# Altium schematic sheet Current_Measurement_AB.SchDoc(Current_Measurement_AB)
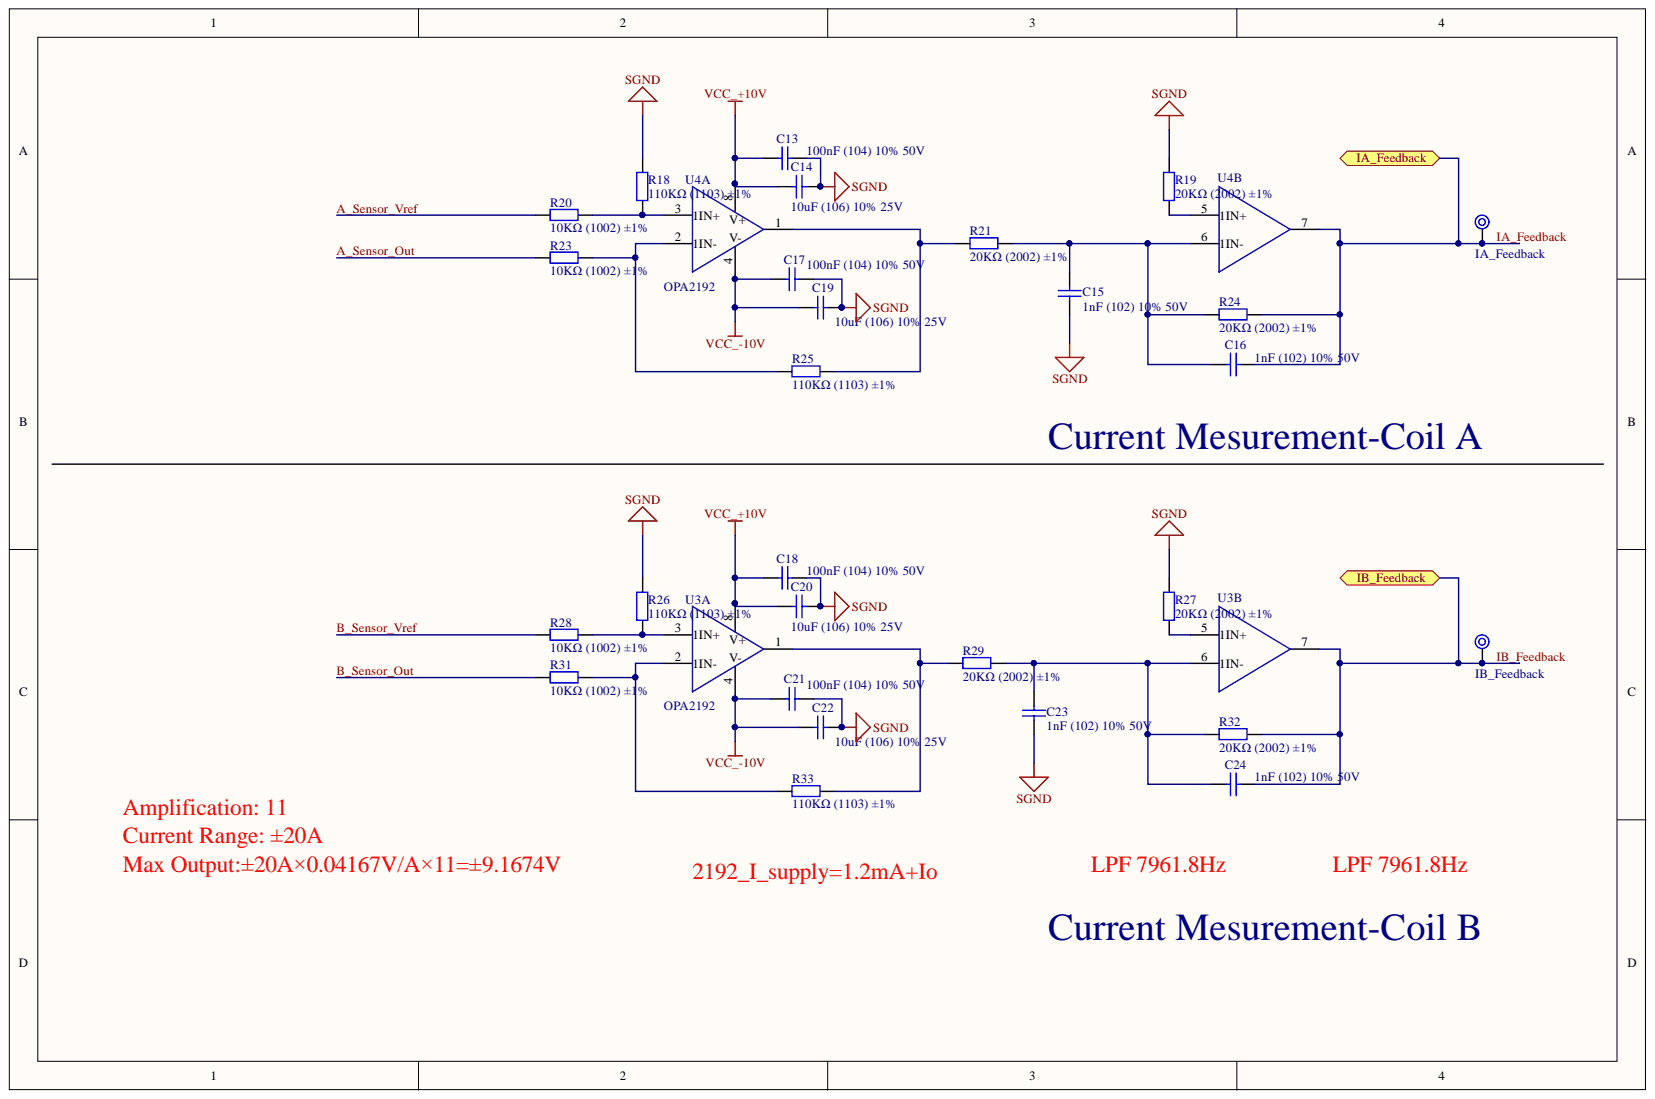
[diagram p0: Current_Measurement_AB.SchDoc]

## Parts
C13  100nF (104) 10% 50V  [0603_C]
C14  10uF (106) 10% 25V  [0805_C]
C15  1nF (102) 10% 50V  [0603_C]
C16  1nF (102) 10% 50V  [0603_C]
C17  100nF (104) 10% 50V  [0603_C]
C18  100nF (104) 10% 50V  [0603_C]
C19  10uF (106) 10% 25V  [0805_C]
C20  10uF (106) 10% 25V  [0805_C]
C21  100nF (104) 10% 50V  [0603_C]
C22  10uF (106) 10% 25V  [0805_C]
C23  1nF (102) 10% 50V  [0603_C]
C24  1nF (102) 10% 50V  [0603_C]
IA_Feedback  TP_Surface  [TEST_POINT_ME]  (value not drawn)
IB_Feedback  TP_Surface  [TEST_POINT_ME]  (value not drawn)
R18  110KΩ (1103) ±1%  [0603_R]
R19  20KΩ (2002) ±1%  [0603_R]
R20  10KΩ (1002) ±1%  [0603_R]
R21  20KΩ (2002) ±1%  [0603_R]
R23  10KΩ (1002) ±1%  [0603_R]
R24  20KΩ (2002) ±1%  [0603_R]
R25  110KΩ (1103) ±1%  [0603_R]
R26  110KΩ (1103) ±1%  [0603_R]
R27  20KΩ (2002) ±1%  [0603_R]
R28  10KΩ (1002) ±1%  [0603_R]
R29  20KΩ (2002) ±1%  [0603_R]
R31  10KΩ (1002) ±1%  [0603_R]
R32  20KΩ (2002) ±1%  [0603_R]
R33  110KΩ (1103) ±1%  [0603_R]
U3  OPA2192  [SOIC127P600X175-8N]  (parts A,B)
U4  OPA2192  [SOIC127P600X175-8N]  (parts A,B)

## Ports
IA_Feedback, IB_Feedback

## Nets
SGND: C13.2 C14.2 C15.1 C17.2 C18.2 C19.2 C20.2 C21.2 C22.2 C23.1 R18.2 R19.2 R26.2 R27.2
VCC_+10V: C13.1 C14.1 C18.1 C20.1 U3.8(V+) U4.8(V+)
VCC_-10V: C17.1 C19.1 C21.1 C22.1 U3.4(V-) U4.4(V-)
A_Sensor_Out: R23.1
A_Sensor_Vref: R20.1
B_Sensor_Out: R31.1
B_Sensor_Vref: R28.1
IA_Feedback: C16.2 IA_Feedback.1 R24.2 U4.7 ; port IA_Feedback
IB_Feedback: C24.2 IB_Feedback.1 R32.2 U3.7 ; port IB_Feedback
NetC15_2: C15.2 C16.1 R21.2 R24.1 U4.6(1IN-)
NetC23_2: C23.2 C24.1 R29.2 R32.1 U3.6(1IN-)
NetR18_1: R18.1 R20.2 U4.3(1IN+)
NetR19_1: R19.1 U4.5(1IN+)
NetR21_1: R21.1 R25.2 U4.1
NetR23_2: R23.2 R25.1 U4.2(1IN-)
NetR26_1: R26.1 R28.2 U3.3(1IN+)
NetR27_1: R27.1 U3.5(1IN+)
NetR29_1: R29.1 R33.2 U3.1
NetR31_2: R31.2 R33.1 U3.2(1IN-)

## Library data of the parts
C13 C15-C18 C21 C23 C24 = 0603 100nF (104) 10% 50V: 贴片电容; SuppliersPartNumber: C14663; Supplier: LC; Package: 0603; Library Name: JLC Library.IntLib; ComponentLink1URL: http://www.szlcsc.com/product/details_15331.html; ComponentLink1Description: 供应商链接; Component Kind: Standard
C14 C19 C20 C22 = 0805 10uF (106) 10% 25V: 贴片电容; SuppliersPartNumber: C15850; Supplier: LC; Package: 0805; Library Name: JLC Library.IntLib; ComponentLink1URL: http://www.szlcsc.com/product/details_16532.html; ComponentLink1Description: 供应商链接; Component Kind: Standard
R18 R25 R26 R33 = 0603 110KΩ (1103) 1%: 贴片电阻; SuppliersPartNumber: C25805; Supplier: LC; Package: 0603; Library Name: JLC Library.IntLib; ComponentLink1URL: http://www.szlcsc.com/product/details_26548.html; ComponentLink1Description: 供应商链接; Component Kind: Standard
R19 R21 R24 R27 R29 R32 = 0603 20KΩ (2002) 1%: 贴片电阻; SuppliersPartNumber: C4184; Supplier: LC; Package: 0603; Library Name: JLC Library.IntLib; ComponentLink1URL: http://www.szlcsc.com/product/details_4591.html; ComponentLink1Description: 供应商链接; Component Kind: Standard
R20 R23 R28 R31 = 0603 10KΩ (1002) 1%: 贴片电阻; SuppliersPartNumber: C25804; Supplier: LC; Package: 0603; Library Name: JLC Library.IntLib; ComponentLink1URL: http://www.szlcsc.com/product/details_26547.html; ComponentLink1Description: 供应商链接; Component Kind: Standard
U3 U4 = OPA2192: 精密运放; Component Kind: Standard; Library Name: PSRM_Sensorless_V2.0模块.IntLib
IA_Feedback IB_Feedback = TP_Surface: Component Kind: Standard; Library Name: PSRM_Sensorless_V2.0模块.IntLib
